# Altium schematic sheet [09] - DISPLAY PORT.SchDoc("[09] - DISPLAY PORT")
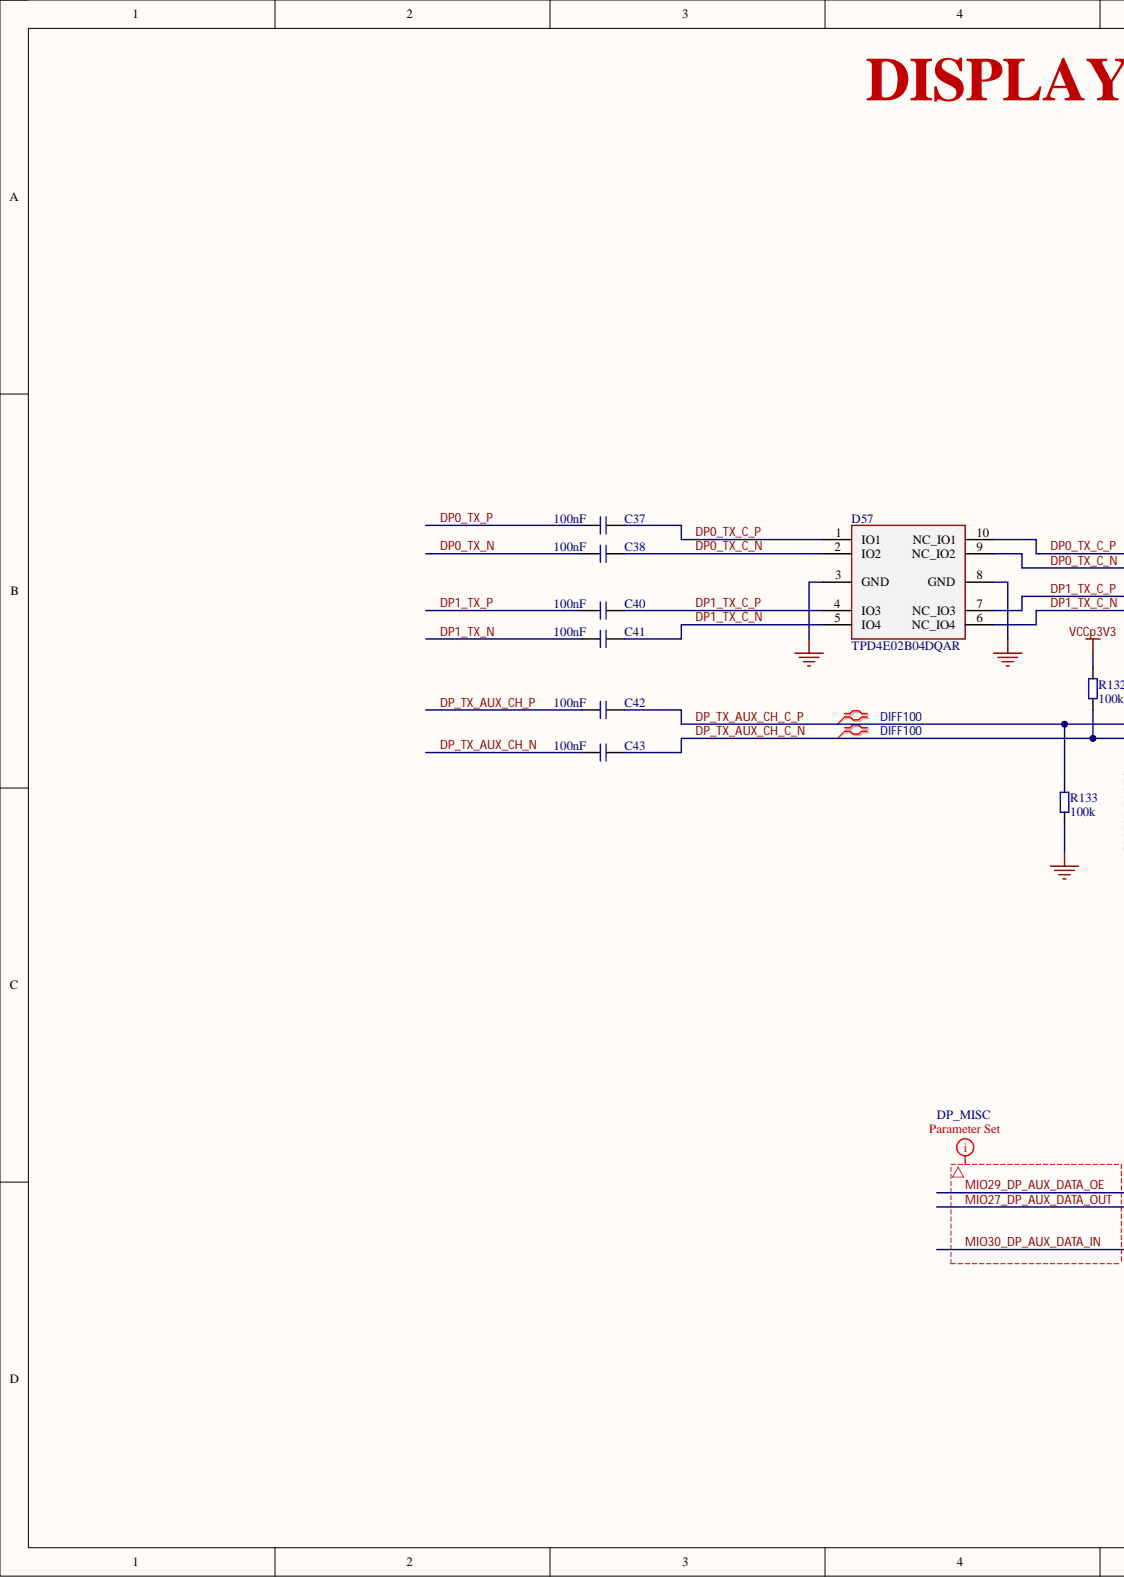
[diagram p0: [09] - DISPLAY PORT.SchDoc, left]
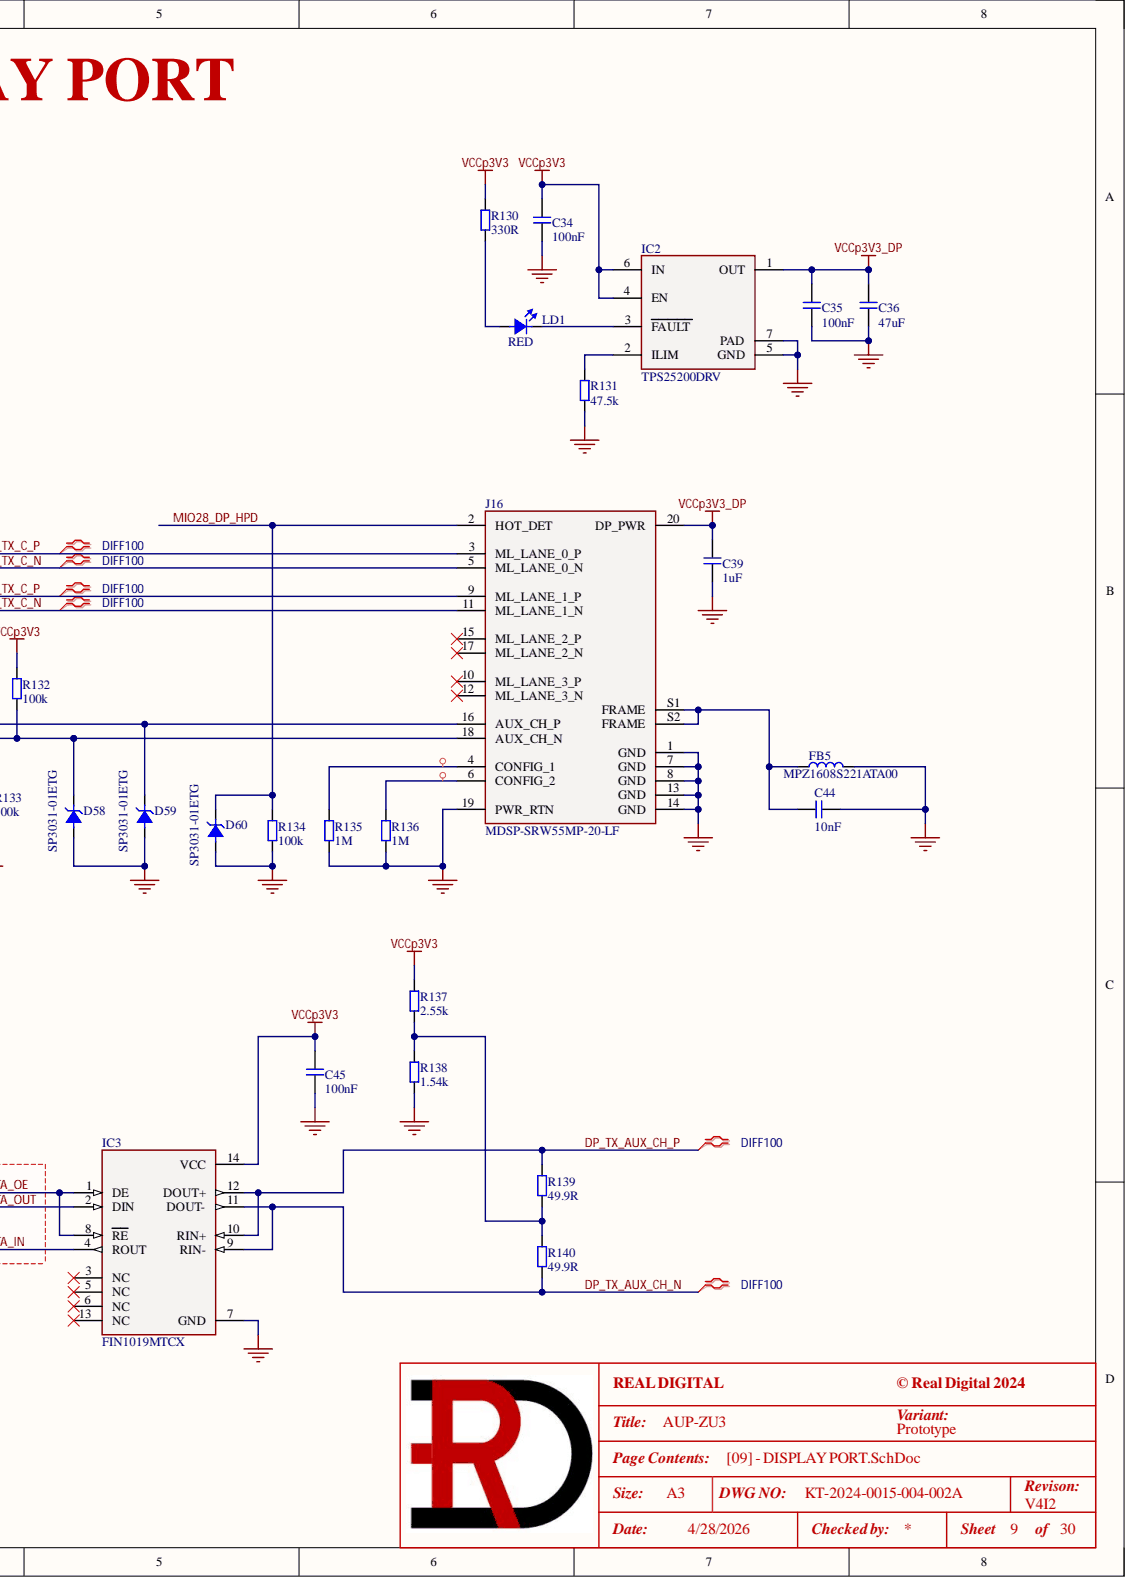
[diagram p1: [09] - DISPLAY PORT.SchDoc, right]

## Parts
C34  100nF  [C0402]
C35  100nF  [C0402]
C36  47uF  [C0805]
C37  100nF  [C0201]
C38  100nF  [C0201]
C39  1uF  [C0603]
C40  100nF  [C0201]
C41  100nF  [C0201]
C42  100nF  [C0201]
C43  100nF  [C0201]
C44  10nF  [C0402]
C45  100nF  [C0402]
D57  TPD4E02B04DQAR  [DQA0010A_UFDFN10 - TI]
D58  SP3031-01ETG  [SOD882 - LITTLEFUSE]
D59  SP3031-01ETG  [SOD882 - LITTLEFUSE]
D60  SP3031-01ETG  [SOD882 - LITTLEFUSE]
FB5  MPZ1608S221ATA00  [MPZ1608]
IC2  TPS25200DRV  [DRV0006A_WSON_6 - TI]
IC3  FIN1019MTCX  [TSSOP-14 - ONSEMI]
J16  MDSP-SRW55MP-20-LF  [MDSP-SRW55MP-20-LF]
LD1  RED  [LD0603_RED]
R130  330R  [R0402]
R131  47.5k  [R0402]
R132  100k  [R0402]
R133  100k  [R0402]
R134  100k  [R0402]
R135  1M  [R0402]
R136  1M  [R0402]
R137  2.55k  [R0402]
R138  1.54k  [R0402]
R139  49.9R  [R0402]
R140  49.9R  [R0402]

## Nets
GND: C34.1 C35.1 C36.1 C39.1 C44.2 C45.1 D57.3(GND) D57.8(GND) D58.A D59.A D60.A FB5.2 IC2.5(GND) IC2.7(PAD) IC3.7(GND) J16.1(GND) J16.7(GND) J16.8(GND) J16.13(GND) J16.14(GND) J16.19(PWR_RTN) R131.1 R133.1 R134.1 R135.1 R136.1 R138.1
VCCp3V3: C34.2 C45.2 IC2.4(EN) IC2.6(IN) IC3.14(VCC) R130.2 R132.2 R137.2
VCCp3V3_DP: C35.2 C36.2 C39.2 IC2.1(OUT) J16.20(DP_PWR)
DP0_TX_C_N: C38.2 D57.2(IO2) D57.9(NC_IO2) J16.5(ML_LANE_0_N)
DP0_TX_C_P: C37.2 D57.1(IO1) D57.10(NC_IO1) J16.3(ML_LANE_0_P)
DP0_TX_N: C38.1
DP0_TX_P: C37.1
DP1_TX_C_N: C41.2 D57.5(IO4) D57.6(NC_IO4) J16.11(ML_LANE_1_N)
DP1_TX_C_P: C40.2 D57.4(IO3) D57.7(NC_IO3) J16.9(ML_LANE_1_P)
DP1_TX_N: C41.1
DP1_TX_P: C40.1
DP_TX_AUX_CH_C_N: C43.2 D58.K J16.18(AUX_CH_N) R132.1
DP_TX_AUX_CH_C_P: C42.2 D59.K J16.16(AUX_CH_P) R133.2
DP_TX_AUX_CH_N: C43.1 IC3.9(RIN-) IC3.11(DOUT-) R140.1
DP_TX_AUX_CH_P: C42.1 IC3.10(RIN+) IC3.12(DOUT+) R139.2
MIO27_DP_AUX_DATA_OUT: IC3.2(DIN)
MIO28_DP_HPD: D60.K J16.2(HOT_DET) R134.2
MIO29_DP_AUX_DATA_OE: IC3.1(DE) IC3.8(RE)
MIO30_DP_AUX_DATA_IN: IC3.4(ROUT)
NetC44_1: C44.1 FB5.1 J16.S1(FRAME) J16.S2(FRAME)
NetIC2_2: IC2.2(ILIM) R131.2
NetIC2_3: IC2.3(FAULT) LD1.K
NetJ16_4: J16.4(CONFIG_1) R135.2
NetJ16_6: J16.6(CONFIG_2) R136.2
NetLD1_A: LD1.A R130.1
NetR137_1: R137.1 R138.2 R139.1 R140.2

Not connected: IC3 3(NC) 5(NC) 6(NC) 13(NC); J16 10(ML_LANE_3_P) 12(ML_LANE_3_N) 15(ML_LANE_2_P) 17(ML_LANE_2_N)

## Library data of the parts
C34 C35 C45 = GRM155R71E104KE14J: CAP CER 0.1uF 25V X7R 0402; Manufacturer 1: Murata Electronics; .Checked_PCB: AC; .Creator: RV; C P/N: RD-111-0006; LoadStatus: Load; Tolerance: 10%; Category: Capacitors; Component Kind: Standard; Library Name: KT-RealDigital.IntLib; Manufacturer 1 Part Number: GRM155R71E104KE14J; Temperature Coefficient: X7R; Voltage - Rated: 25V; .Checked_SCH: AC
C36 = GRM21BR61A476ME15L: CAP CER 47uF 10V X5R 0805; Manufacturer 1: Murata Electronics; .Checked_PCB: RV; C P/N: RD-111-0049; LoadStatus: Load; Tolerance: 20%; Category: Capacitors; Component Kind: Standard; Library Name: KT-RealDigital.IntLib; Manufacturer 1 Part Number: GRM21BR61A476ME15L; Temperature Coefficient: X5R; Voltage - Rated: 10V; .Checked_SCH: RV; .Creator: RV
C37 C38 C40-C43 = GRM033C71C104KE14D: CAP CER 0.1uF 16V X7S 0201; Manufacturer 1: Murata Electronics; .Checked_PCB: RV; C P/N: 111-0800; LoadStatus: Load; Tolerance: 10%; Category: Capacitors; Component Kind: Standard; Library Name: KT-RealDigital.IntLib; Manufacturer 1 Part Number: GRM033C71C104KE14D; Temperature Coefficient: X7S; Voltage - Rated: 16V; .Checked_SCH: RV; .Creator: RV
C39 = GCJ188R71E105KA01D: CAP CER 1uF 25V X7R 0603; Manufacturer 1: Murata Electronics; .Checked_PCB: AC; .Creator: RV; C P/N: 111-0602; LoadStatus: Load; Tolerance: 10%; Category: Capacitors; Component Kind: Standard; Library Name: KT-RealDigital.IntLib; Manufacturer 1 Part Number: GCJ188R71E105KA01D; Temperature Coefficient: X7R; Voltage - Rated: 25V; .Checked_SCH: AC
C44 = GRM155R71H103KA88J: CAP CER 10000pF 50V X7R 0402; Manufacturer 1: Murata Electronics; .Checked_PCB: AC; .Creator: RV; C P/N: RD-111-0003; LoadStatus: Load; Tolerance: 10%; Category: Capacitors; Component Kind: Standard; Library Name: KT-RealDigital.IntLib; Manufacturer 1 Part Number: GRM155R71H103KA88J; Temperature Coefficient: X7R; Voltage - Rated: 50V; .Checked_SCH: AC
D57 = TPD4E02B04DQAR: TVS DIODE 3.6V 8.8V 10USON; Manufacturer 1: Texas Instruments; .Checked_PCB: RV; C P/N: 121-0517; LoadStatus: Load; Category: TVS - Diodes; Component Kind: Standard; Library Name: KT-RealDigital.IntLib; Manufacturer 1 Part Number: TPD4E02B04DQAR; .Checked_SCH: RV; .Creator: RV
D58-D60 = SP3031-01ETG: TVS DIODE 5V 7.5V SOD882; Manufacturer 1: Littelfuse Inc.; .Checked_PCB: RV; C P/N: RD-121-0004; LoadStatus: Load; Category: TVS - Diodes; Component Kind: Standard; Library Name: KT-RealDigital.IntLib; Manufacturer 1 Part Number: SP3031-01ETG; .Checked_SCH: RV; .Creator: RV
FB5 = MPZ1608S221ATA00: FERRITE BEAD 220 OHM 0603 1LN; Manufacturer 1: TDK Corporation; .Checked_PCB: AC; .Checked_SCH: RV; C P/N: CY-121-0068; LoadStatus: Load; Category: Ferrite Beads; Component Kind: Standard; Library Name: KT-RealDigital.IntLib; Manufacturer 1 Part Number: MPZ1608S221ATA00; .Creator: RV
IC2 = TPS25200DRV: IC PWR MGR EFUSE 6.5V 2.8A 6SON; Manufacturer 1: Texas Instruments; .Checked_PCB: RV; C P/N: RD-126-0094; LoadStatus: Load; Category: IC; Component Kind: Standard; Library Name: KT-RealDigital.IntLib; Manufacturer 1 Part Number: TPS25200DRV; .Checked_SCH: RV; .Creator: RV
IC3 = FIN1019MTCX: IC TRANSCEIVER FULL 1/1 14TSSOP; Manufacturer 1: ON Semiconductor; .Checked_PCB: RV; C P/N: 126-1510; LoadStatus: Load; Category: IC; Component Kind: Standard; Library Name: KT-RealDigital.IntLib; Manufacturer 1 Part Number: FIN1019MTCX; .Checked_SCH: RV; .Creator: RV
J16 = MDSP-SRW55MP-20-LF: Conn Display Port RCP 20 POS Solder RA SMD/Thru-Hole 20; Manufacturer 1: Morethanall Co., Ltd.; .Checked_PCB: RV; C P/N: RD-163-0017; LoadStatus: Load; Category: Connectors; Component Kind: Standard; Library Name: KT-RealDigital.IntLib; Manufacturer 1 Part Number: MDSP-SRW55MP-20-LF; .Checked_SCH: RV; .Creator: RV
LD1 = LED_RED: LED RED 0603; Manufacturer 1: EVERLIGHT ELECTRONICS CO.,LTD.; Manufacturer 1 Part Number: 19-21SURC/S530-XX/TR8; .Checked_PCB: AC; .Creator: RV; C P/N: 121-0001; LoadStatus: Load; Category: Led; Component Kind: Standard; Library Name: KT-RealDigital.IntLib; .Checked_SCH: AC
R130 = RC0402JR-07330RL: RES SMD 330 OHM 5% 1/16W 0402; Manufacturer 1: Yageo; .Checked_PCB: AC; .Creator: RV; C P/N: 101-0112; LoadStatus: Load; Tolerance: 5%/TK100; Category: Resistors; Component Kind: Standard; Library Name: KT-RealDigital.IntLib; Manufacturer 1 Part Number: RC0402JR-07330RL; .Checked_SCH: AC
R131 = RC0402FR-0747K5L: RES SMD 47.5K OHM 1% 1/16W 0402; Manufacturer 1: Yageo; .Checked_PCB: RV; C P/N: CY-101-0400; LoadStatus: Load; Tolerance: 1%/TK100; Category: Resistors; Component Kind: Standard; Library Name: KT-RealDigital.IntLib; Manufacturer 1 Part Number: RC0402FR-0747K5L; .Checked_SCH: RV; .Creator: RV
R132-R134 = RC0402FR-07100KL: RES SMD 100K OHM 1% 1/16W 0402; Manufacturer 1: Yageo; .Checked_PCB: RV; C P/N: RD-101-0013; LoadStatus: Load; Tolerance: 1%/TK100; Category: Resistors; Component Kind: Standard; Library Name: KT-RealDigital.IntLib; Manufacturer 1 Part Number: RC0402FR-07100KL; .Checked_SCH: RV; .Creator: RV
R135 R136 = RC0402FR-071ML: RES SMD 1M OHM 1% 1/16W 0402; Manufacturer 1: YAGEO; .Checked_PCB: RV; C P/N: RD-101-0014; LoadStatus: Load; Tolerance: 1%/TK100; Category: Resistors; Component Kind: Standard; Library Name: KT-RealDigital.IntLib; Manufacturer 1 Part Number: RC0402FR-071ML; .Checked_SCH: RV; .Creator: RV
R137 = RC0402FR-072K55L: RES SMD 2.55K OHM 1% 1/16W 0402; Manufacturer 1: Yageo; .Checked_PCB: RV; C P/N: RD-101-0126; LoadStatus: Load; Tolerance: 1%/TK100; Category: Resistors; Component Kind: Standard; Library Name: KT-RealDigital.IntLib; Manufacturer 1 Part Number: RC0402FR-072K55L; .Checked_SCH: RV; .Creator: RV
R138 = RC0402FR-071K54L: RES SMD 1.54K OHM 1% 1/16W 0402; Manufacturer 1: Yageo; .Checked_PCB: RV; C P/N: RD-101-0015; LoadStatus: Load; Tolerance: 1%/TK100; Category: Resistors; Component Kind: Standard; Library Name: KT-RealDigital.IntLib; Manufacturer 1 Part Number: RC0402FR-071K54L; .Checked_SCH: RV; .Creator: RV
R139 R140 = RC0402FR-0749R9L: RES SMD 49.9 OHM 1% 1/16W 0402; Manufacturer 1: Yageo; .Checked_PCB: RV; C P/N: RD-101-0016; LoadStatus: Load; Tolerance: 1%/TK100; Category: Resistors; Component Kind: Standard; Library Name: KT-RealDigital.IntLib; Manufacturer 1 Part Number: RC0402FR-0749R9L; .Checked_SCH: RV; .Creator: RV
